# Altium schematic sheet Controller.SchDoc
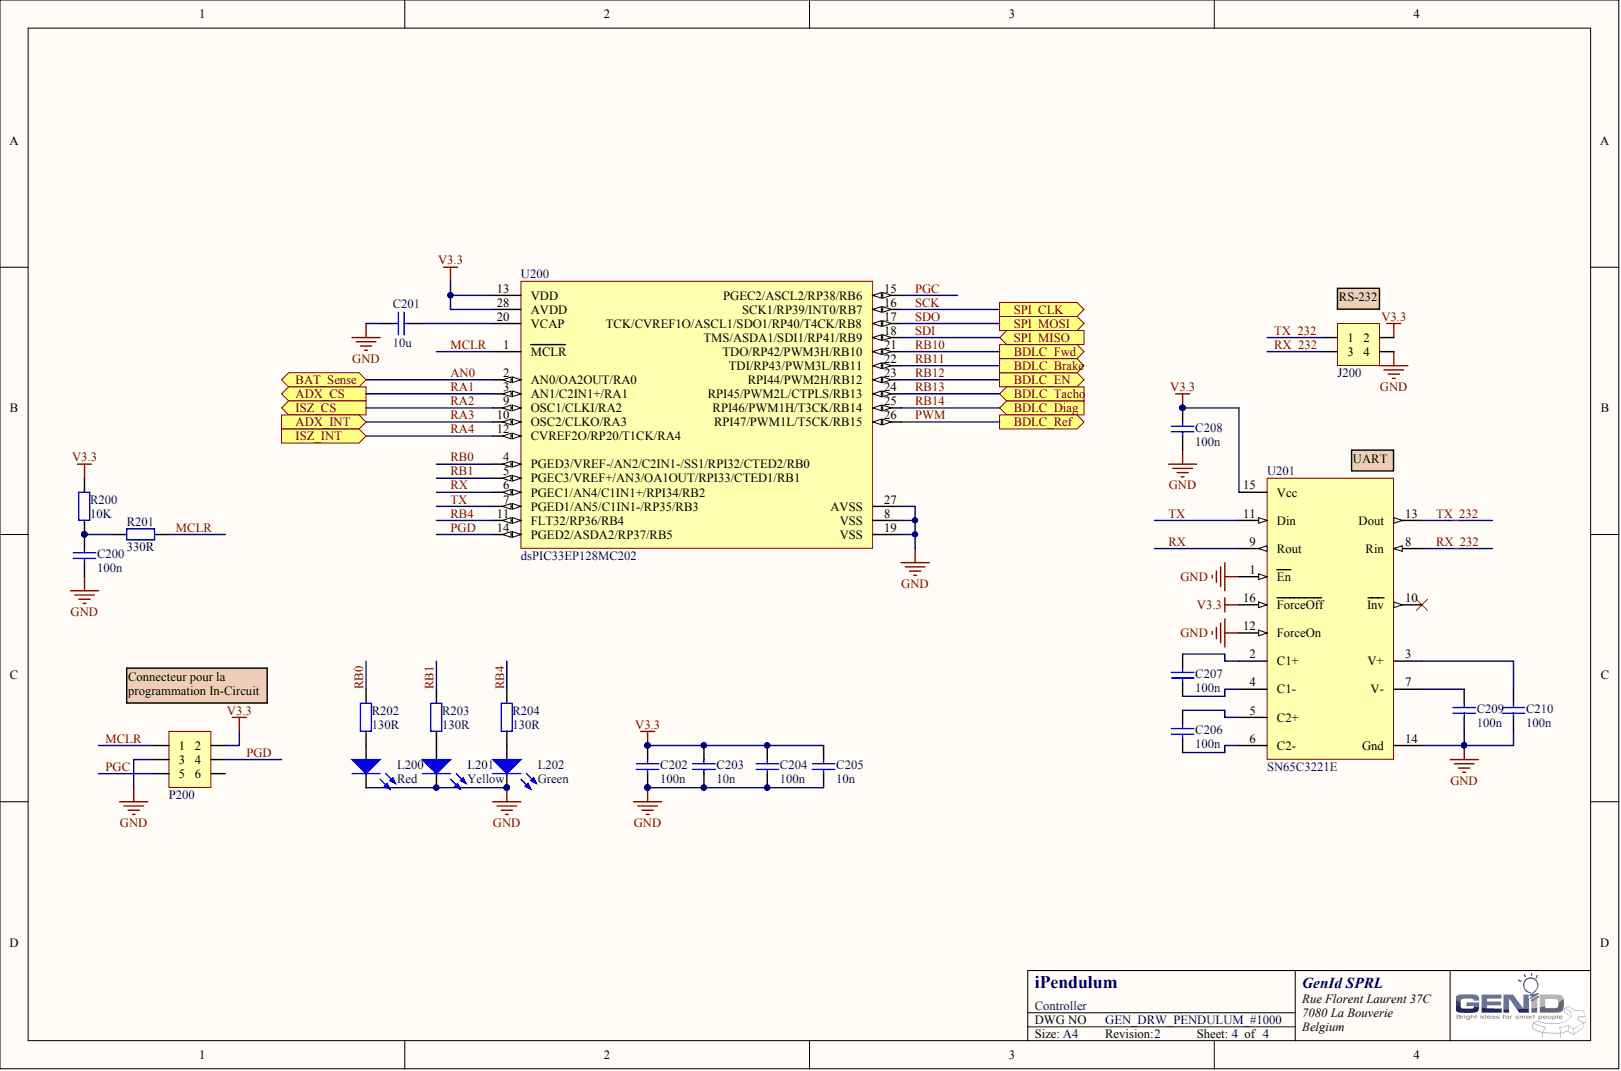
[diagram p0: Controller.SchDoc]

## Parts
C200  value 100n  [0805-CAP]
C201  value 10u  [1206-CAP]
C202  value 100n  [0805-CAP]
C203  value 10n  [0805-CAP]
C204  value 100n  [0805-CAP]
C205  value 10n  [0805-CAP]
C206  value 100n  [0805-CAP]
C207  value 100n  [0805-CAP]
C208  value 100n  [0805-CAP]
C209  value 100n  [0805-CAP]
C210  value 100n  [0805-CAP]
J200  (no value)  [C-GRID3-2x2]
L200  Color: Red  [1206-LED-RED]
L201  Color: Yellow  [1206-LED-YELLOW]
L202  Color: Green  [1206-LED-GREEN]
P200  (no value)  [C-GRID3-2x3]
R200  value 10K  [SMD 0805]
R201  value 330R  [SMD 0805]
R202  value 130R  [SMD 0805]
R203  value 130R  [SMD 0805]
R204  value 130R  [SMD 0805]
U200  (no value)  [SSOP_28]
U201  (no value)  [SSOP_16]

## Ports
ADX_CS, ADX_INT, BAT_SENSE, BDLC_BRAKE, BDLC_DIAG, BDLC_EN, BDLC_FWD, BDLC_REF, BDLC_TACHO, ISZ_CS, ISZ_INT, SPI_CLK, SPI_MISO, SPI_MOSI

## Nets
GND: C200.2 C201.2 C202.2 C203.2 C204.2 C205.2 C208.2 C209.2 C210.2 J200.4 L200.2 L201.2 L202.2 P200.3 U200.8(VSS) U200.19(VSS) U200.27(AVSS) U201.1(En) U201.12(ForceOn) U201.14(Gnd)
V3.3: C202.1 C203.1 C204.1 C205.1 C208.1 J200.2 P200.2 R200.2 U200.13(VDD) U200.28(AVDD) U201.15(Vcc) U201.16(ForceOff)
AN0: U200.2(AN0/OA2OUT/RA0) ; port BAT_SENSE
MCLR: P200.1 R201.2 U200.1(MCLR)
PGC: P200.5 U200.15(PGEC2/ASCL2/RP38/RB6)
PGD: P200.4 U200.14(PGED2/ASDA2/RP37/RB5)
PWM: U200.26(RPI47/PWM1L/T5CK/RB15) ; port BDLC_REF
RA1: U200.3(AN1/C2IN1+/RA1) ; port ADX_CS
RA2: U200.9(OSC1/CLKI/RA2) ; port ISZ_CS
RA3: U200.10(OSC2/CLKO/RA3) ; port ADX_INT
RA4: U200.12(CVREF2O/RP20/T1CK/RA4) ; port ISZ_INT
RB0: R202.1 U200.4(PGED3/VREF-/AN2/C2IN1-/SS1/RPI32/CTED2/RB0)
RB1: R203.1 U200.5(PGEC3/VREF+/AN3/OA1OUT/RPI33/CTED1/RB1)
RB4: R204.1 U200.11(FLT32/RP36/RB4)
RB10: U200.21(TDO/RP42/PWM3H/RB10) ; port BDLC_FWD
RB11: U200.22(TDI/RP43/PWM3L/RB11) ; port BDLC_BRAKE
RB12: U200.23(RPI44/PWM2H/RB12) ; port BDLC_EN
RB13: U200.24(RPI45/PWM2L/CTPLS/RB13) ; port BDLC_TACHO
RB14: U200.25(RPI46/PWM1H/T3CK/RB14) ; port BDLC_DIAG
RX: U200.6(PGEC1/AN4/C1IN1+/RPI34/RB2) U201.9(Rout)
RX_232: J200.3 U201.8(Rin)
SCK: U200.16(SCK1/RP39/INT0/RB7) ; port SPI_CLK
SDI: U200.18(TMS/ASDA1/SDI1/RP41/RB9) ; port SPI_MISO
SDO: U200.17(TCK/CVREF1O/ASCL1/SDO1/RP40/T4CK/RB8) ; port SPI_MOSI
TX: U200.7(PGED1/AN5/C1IN1-/RP35/RB3) U201.11(Din)
TX_232: J200.1 U201.13(Dout)
NetC200_1: C200.1 R200.1 R201.1
NetC201_1: C201.1 U200.20(VCAP)
NetC206_1: C206.1 U201.5(C2+)
NetC206_2: C206.2 U201.6(C2-)
NetC207_1: C207.1 U201.2(C1+)
NetC207_2: C207.2 U201.4(C1-)
NetC209_1: C209.1 U201.7(V-)
NetC210_1: C210.1 U201.3(V+)
NetL200_1: L200.1 R202.2
NetL201_1: L201.1 R203.2
NetL202_1: L202.1 R204.2

Not connected: P200 6; U201 10(Inv)

## Library data of the parts
C200 C202 C204 C206-C210 = C0805C104K5RA: 100n-0805-50V-10%-X7R; Manufacturer: Kemet; Dielectric: X7R; Tolerance: 10%; Voltage: 50V; Component Kind: Standard; HelpURL: http://www.kemet.com/kemet/web/homepage/kechome.nsf/weben/DC9E4F3CDBEF8272852579290047C2CA/$file/KEM_CC101_COMM_SMD.pdf; Library Name: libCapacitors; Reference: C0805C104K5RA
C201 = C1206C106K4RA: 10u-1206-16V-10%-X7R; Manufacturer: Kemet; Dielectric: X7R; Tolerance: 10%; Voltage: 16V; Component Kind: Standard; HelpURL: http://www.kemet.com/kemet/web/homepage/kechome.nsf/weben/DC9E4F3CDBEF8272852579290047C2CA/$file/KEM_CC101_COMM_SMD.pdf; Library Name: libCapacitors; Reference: C1206C106K4RA
C203 C205 = C0805C103K5RA: 10n-0805-50V-10%-X7R; Manufacturer: Kemet; Dielectric: X7R; Tolerance: 10%; Voltage: 50V; Component Kind: Standard; HelpURL: http://www.kemet.com/kemet/web/homepage/kechome.nsf/weben/DC9E4F3CDBEF8272852579290047C2CA/$file/KEM_CC101_COMM_SMD.pdf; Library Name: libCapacitors; Reference: C0805C103K5RA
J200 = C-GRID3-2x2-90131-1082: 2.54mm Pitch C-Grid III Header, Dual Row, Vertical, 4 Circuits, 0.38m Gold (Au); Manufacturer: Molex; Component Kind: Standard; HelpURL: http://www.molex.com/molex/products/datasheet.jsp?part=active/0901311082_PCB_HEADERS.xml; Library Name: libConnectors; Reference: 90131-1082
L200 = KP-3216EC: LED, SMD, 1206, RED; Manufacturer: Kingbright; If: 20mA; Vf: 2V; View angle: 120°; Component Kind: Standard; HelpURL: http://www.kingbright.com/manager/upload/pdf/KP-3216EC%28Ver1189143658.12%29; Library Name: libLED; Reference: KP-3216EC
L201 = KP-3216YC: LED, SMD, 1206, YELLOW; Manufacturer: Kingbright; If: 20mA; Vf: 2V; View angle: 120°; Component Kind: Standard; Library Name: libLED.IntLib; Reference: KP-3216YC
L202 = KP-3216SGC: LED, SMD, 1206, GREEN; Manufacturer: Kingbright; If: 20mA; Vf: 2V; View angle: 120°; Component Kind: Standard; Library Name: libLED; Reference: KP-3216SGC
P200 = C-GRID3-2x3-90131-0763: 2.54mm Pitch C-Grid III Header, Dual Row, Vertical, 6 Circuits, 0.38m Gold (Au); Manufacturer: Molex; Component Kind: Standard; HelpURL: http://www.molex.com/molex/products/datasheet.jsp?part=active/0901310763_PCB_HEADERS.xml; Library Name: libConnectors; Reference: 90131-0763
R200 = CRCW080510K0FKEA: 10K-0805-0.125W-1%-100ppm; Manufacturer: Vishay; Power: 1/8W; T. Coeff: 100ppm; Tolerance: 1%; Vmax: 150V; Component Kind: Standard; HelpURL: http://www.vishay.com/docs/20035/dcrcwe3.pdf; Library Name: libResistor.IntLib; Reference: CRCW080510K0FKEA
R201 = CRCW0805330RFKEA: 330R-0805-0.125W-1%-100ppm; Manufacturer: Vishay; Power: 1/8W; T. Coeff: 100ppm; Tolerance: 1%; Vmax: 150V; Component Kind: Standard; HelpURL: http://www.vishay.com/docs/20035/dcrcwe3.pdf; Library Name: libResistor.IntLib; Reference: CRCW0805330RFKEA
R202-R204 = CRCW0805130RFKEA: 130R-0805-0.125W-1%-100ppm; Manufacturer: Vishay; Power: 1/8W; T. Coeff: 100ppm; Tolerance: 1%; Vmax: 150V; Component Kind: Standard; HelpURL: http://www.vishay.com/docs/20035/dcrcwe3.pdf; Library Name: libResistor; Reference: CRCW0805130RFKEA
U200 = dsPIC33EP128MC202: 16-Bit Microcontrollers and Digital Signal Controllers with High-Speed PWM, Op Amps and Advanced Analog; Manufacturer: Microchip; Component Kind: Standard; HelpURL: http://ww1.microchip.com/downloads/en/DeviceDoc/70000657H.pdf; Library Name: libIC-Controllers.IntLib; Reference: dsPIC33EP128MC202
U201 = SN65C3221E: 3-V TO 5.5-V SINGLE-CHANNEL RS-232 1-Mbit/s LINE DRIVER/RECEIVER; Manufacturer: Texas Instruments; Component Kind: Standard; ComponentLink1Description: Manufacturer Link; ComponentLink1URL: http://www.ti.com/product/sn65c3221e; ComponentLink2Description: Datasheet; ComponentLink2URL: http://www.ti.com/lit/ds/symlink/sn65c3221e.pdf; Library Name: libIC-Interfaces; Reference: SN65C3221E
